# Altium schematic sheet 06_POWER-INPUT.SchDoc
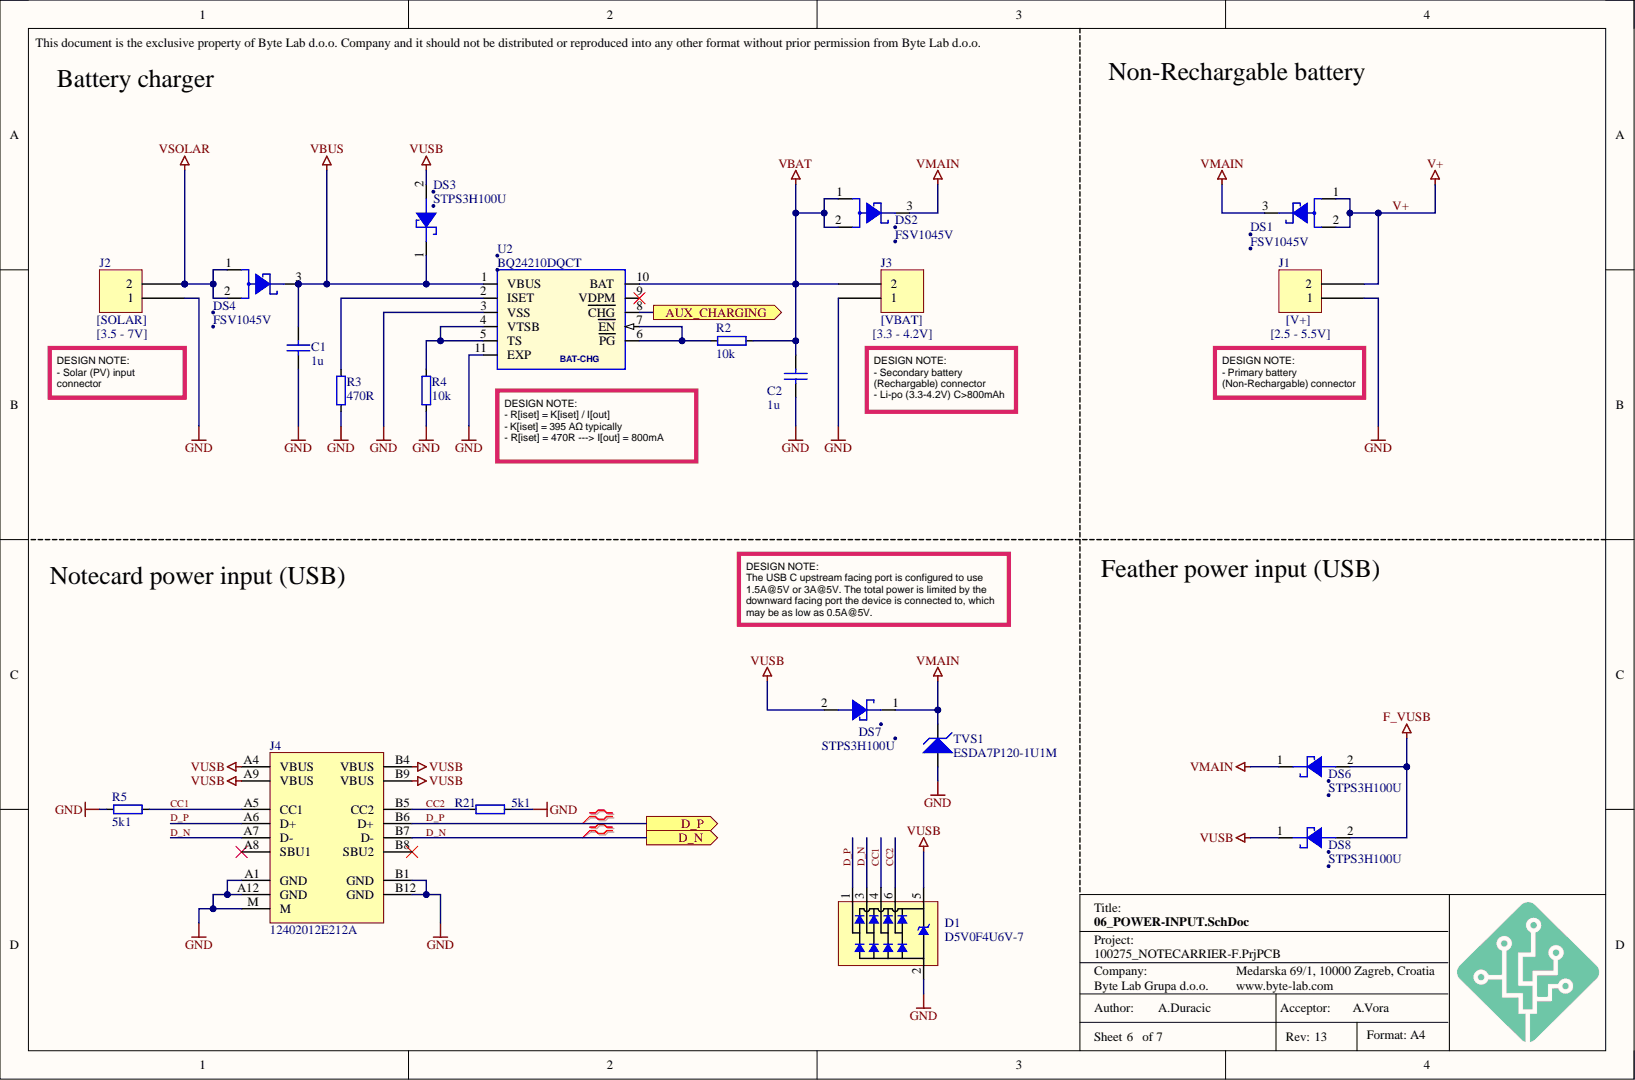
[diagram p0: 06_POWER-INPUT.SchDoc]

## Parts
C1  1u  [C-0603]
C2  1u  [C-0603]
D1  D5V0F4U6V-7  [SOT-563]
DS1  FSV1045V  [TO277-3]
DS2  FSV1045V  [TO277-3]
DS3  STPS3H100U  [SMB]
DS4  FSV1045V  [TO277-3]
DS6  STPS3H100U  [SMB]
DS7  STPS3H100U  [SMB]
DS8  STPS3H100U  [SMB]
J1  S2BPHSM4TBLFSN  [JST S2B-PH-SM4-TB]  (value not drawn)
J2  S2BPHSM4TBLFSN  [JST S2B-PH-SM4-TB]  (value not drawn)
J3  S2BPHSM4TBLFSN  [JST S2B-PH-SM4-TB]  (value not drawn)
J4  12402012E212A  [CONN_12402012E212A]
R2  10k  [R-0402]
R3  470R  [R-0402]
R4  10k  [R-0402]
R5  5k1  [R-0402]
R21  5k1  [R-0402]
TVS1  ESDA7P120-1U1M  [DIOC_160X100X60]
U2  BQ24210DQCT  [WSON10-EXP]

## Ports
AUX_CHARGING, D_N, D_P

## Nets
F_VUSB: DS6.2 DS8.2
GND: C1.1 C2.1 D1.2 J1.1 J2.1 J3.1 J4.A1(GND) J4.A12(GND) J4.B1(GND) J4.B12(GND) J4.M R3.1 R4.1 R5.1 R21.2 TVS1.1 U2.3(VSS) U2.11(EXP)
VBAT: C2.2 DS2.1 DS2.2 J3.2 R2.2 U2.10(BAT)
VBUS: C1.2 DS3.1 DS4.3 U2.1(VBUS)
VMAIN: DS1.3 DS2.3 DS6.1 DS7.1 TVS1.2
VSOLAR: DS4.1 DS4.2 J2.2
VUSB: D1.5 DS3.2 DS7.2 DS8.1 J4.A4(VBUS) J4.A9(VBUS) J4.B4(VBUS) J4.B9(VBUS)
AUX_CHARGING: U2.8(CHG) ; port AUX_CHARGING
CC1: D1.4 J4.A5(CC1) R5.2
CC2: D1.6 J4.B5(CC2) R21.1
D_N: D1.3 J4.A7(D-) J4.B7(D-) ; port D_N
D_P: D1.1 J4.A6(D+) J4.B6(D+) ; port D_P
V+: DS1.1 DS1.2 J1.2
NetR2_1: R2.1 U2.6(PG) U2.7(EN)
NetR3_2: R3.2 U2.2(ISET)
NetR4_2: R4.2 U2.4(VTSB) U2.5(TS)

Not connected: J4 A8(SBU1) B8(SBU2); U2 9(VDPM)

## Library data of the parts
C1 C2 = C0603-1u-25V-X5R: Capacitor ceramic 1u ±10% X5R 25V; BL Part Number: 2006-223; Manufacturer: Yageo; Manufacturer Part Number: CC0603KRX5R8BB105; Checked_By: Golubic; Drawn_By: Hamin; Component Kind: Standard; DateModified: 2022-10-07; Library Name: BL_Passive.SchLib; Supplier 1: Digi-Key; Supplier Part Number 1: 311-1445-1-ND
D1 = D5V0F4U6V-7: TVS DIODE 5.5VWM 12VC SOT563; BL Part Number: 2010-391; Manufacturer: Diodes Incorporated; Drawn_By: Duracic; Component Kind: Standard; DateModified: 21-01-2026; Library Name: BL_Active.SchLib; Manufacturer Part Number: D5V0F4U6V-7
DS1 DS2 DS4 = SCHOTTKY - FSV1045V - 10A/45V: DIODO SCHOTTKY 10A 45V FSV1045V TO277-3 SMT; BL Part Number: 2007-712; Manufacturer: onsemi; Drawn_By: Blues Wireless; Component Kind: Standard; Library Name: n21-p2.SchLib; Manufacturer Part Number: FSV1045V; Supplier 1: Digi-Key; Supplier Part Number 1: FSV1045VCT-ND
DS3 DS6-DS8 = SCHOTTKY-STPS3H100U - 3A/100V: DIODO SCHOTTKY 3A 100V STPS3H100U SMB SMT; BL Part Number: 2007-711; Manufacturer: STMicroelectronics; Drawn_By: Blues Wireless; Component Kind: Standard; Library Name: n21-p2.SchLib; Manufacturer Part Number: STPS3H100U; Supplier 1: Digi-Key; Supplier Part Number 1: 497-10392-1-ND
J1-J3 = S2BPHSM4TBLFSN: PH SMT SIDE ENTRY HDR 2W; BL Part Number: 2007-662; Manufacturer: JST; Manufacturer Part Number: S2B-PH-SM4-K-TB (LF)(SN); Drawn_By: Blues Wireless; Component Kind: Standard; Library Name: n21-p2.SchLib; Supplier 1: Digi-Key
J4 = Conn USB C 12402012E212A: CONN RCP USB2.0 TYP C 24P SMD RA; BL Part Number: 2008-437; Manufacturer: Amphenol; Checked_By: Hlupic; Drawn_By: Anic-Ivicic; Component Kind: Standard; DateModified: 19-03-2024; Library Name: BL_Mechanical.SchLib; Manufacturer Part Number: 12402012E212A; Supplier 1: Digi-Key; Supplier Part Number 1: 664-12402012E212ATR-ND
R2 R4 = R0402-10k-1: Resistor 10k ±1% 0402; BL Part Number: 2000-729; Checked_By: Dodig; Drawn_By: Puskar; Component Kind: Standard; DateModified: 27-08-2013; Library Name: BL_Passive.SchLib; Manufacturer: N/A; Manufacturer Part Number: N/A; Supplier 1: Digi-Key; Supplier Part Number 1: 311-10.0KLRCT-ND
R3 = R0402-470R-1: Resistor 470R ±1% 0402; BL Part Number: 2001-420; Checked_By: Marsic; Drawn_By: Hamin; Component Kind: Standard; DateModified: 10-07-2023; Library Name: BL_Passive.SchLib; Manufacturer: N/A; Manufacturer Part Number: N/A; Supplier 1: Digi-Key; Supplier Part Number 1: 13-RC0402FR-13470RLCT-ND
R5 R21 = R0402-5k1-1: Resistor 5k1 ±1% 0402; BL Part Number: 2004-098; Checked_By: Hamin; Drawn_By: PuskarJ; Component Kind: Standard; DateModified: 21-09-2018; Library Name: BL_Passive.SchLib; Manufacturer: N/A; Manufacturer Part Number: N/A; Supplier 1: Digi-Key; Supplier Part Number 1: P5.10KLCT-ND
TVS1 = ESDA7P120-1U1M: TVS DIODE 5.5VWM 11.5VC 1610; BL Part Number: 2007-378; Manufacturer: STMicroelectronics; Drawn_By: Duracic; Component Kind: Standard; DateModified: 26-01-2026; Library Name: BL_Active.SchLib; Manufacturer Part Number: ESDA7P120-1U1M
U2 = BAT-CHARGE - BQ24210DQCT: INTEGRATO BQ24210DQCT WSON10 TEXAS SMT; BL Part Number: 2005-527; Manufacturer: Texas Instruments; Drawn_By: Blues Wireless; Component Kind: Standard; Library Name: n21-p2.SchLib; Manufacturer Part Number: BQ24210DQCT; Supplier 1: Digi-Key; Supplier Part Number 1: 296-28738-1-ND
